# Altium schematic sheet INPUT.SchDoc(INPUT)
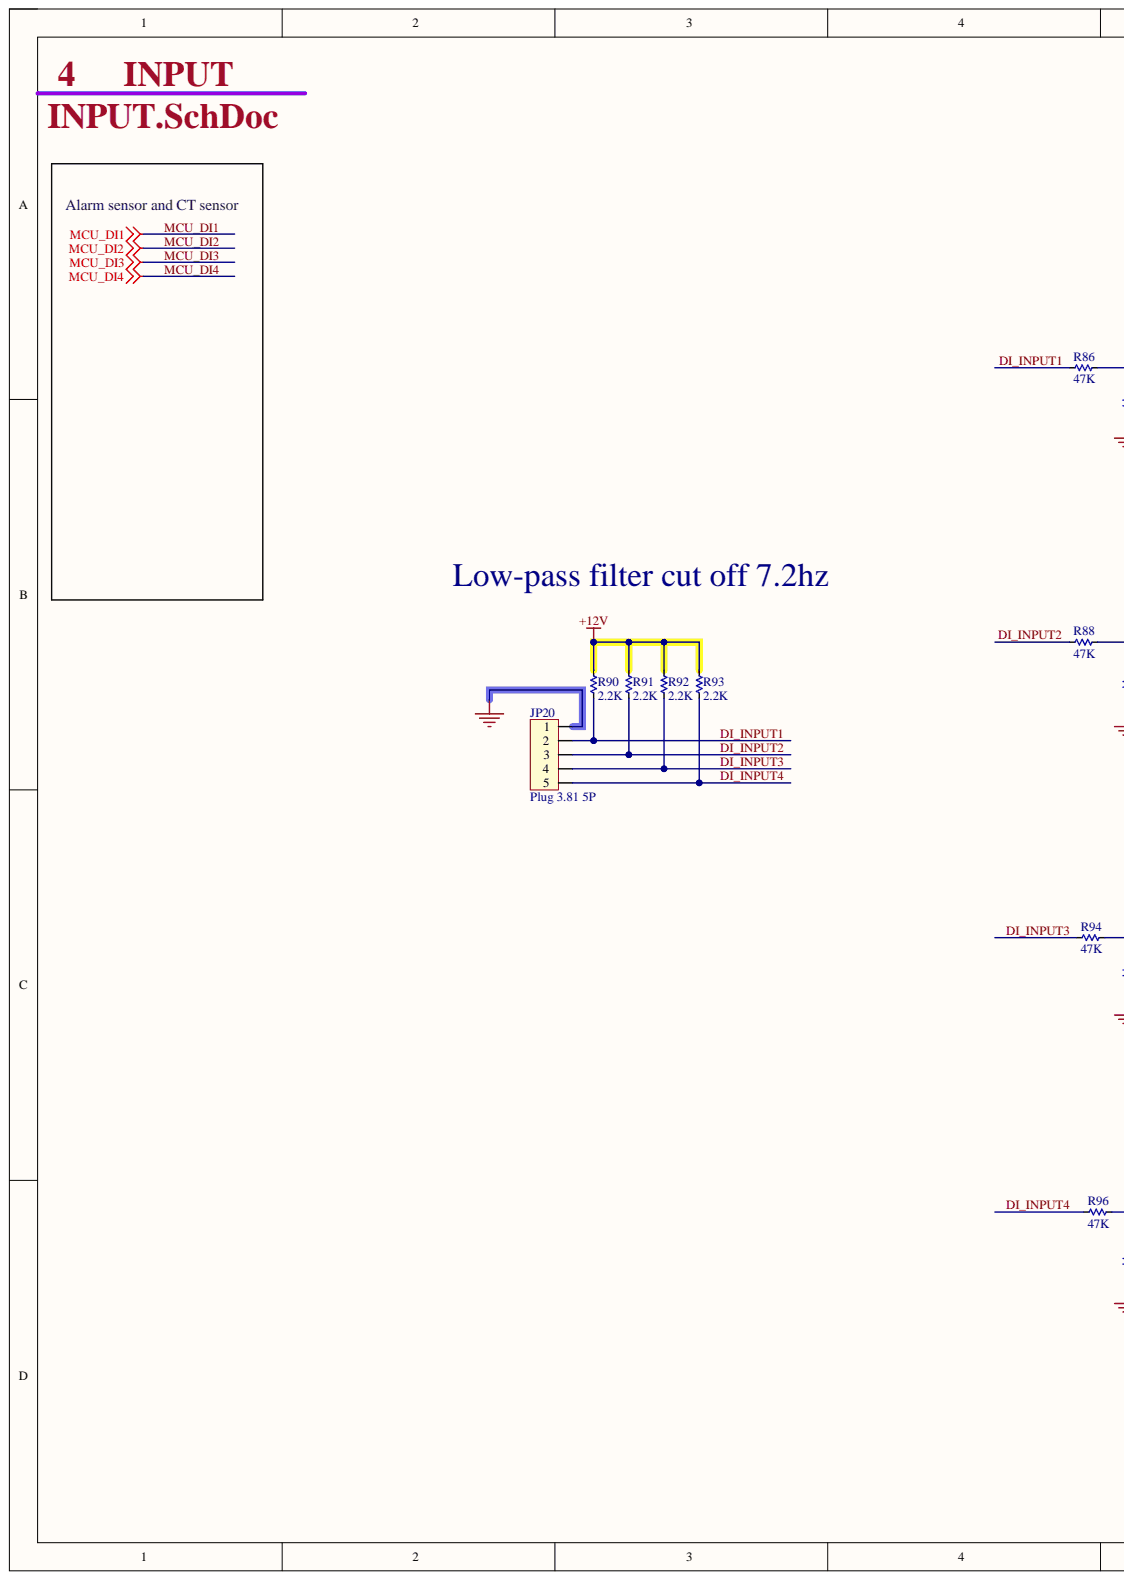
[diagram p0: INPUT.SchDoc, left]
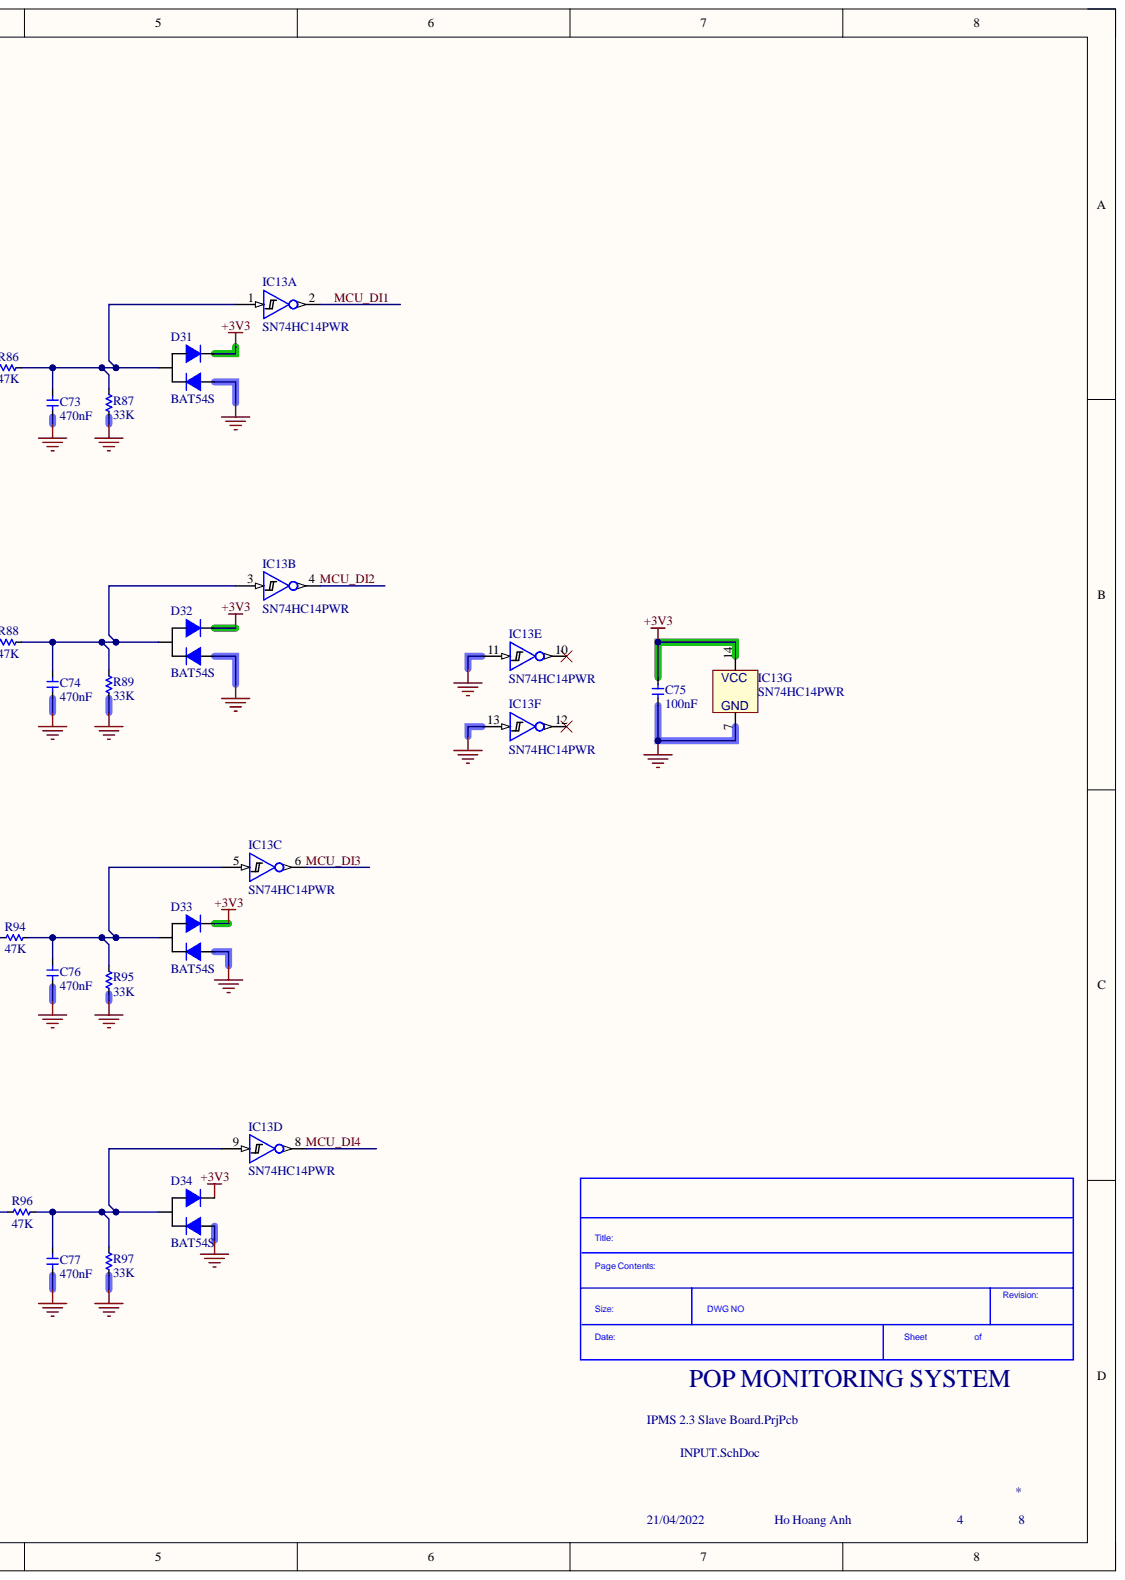
[diagram p1: INPUT.SchDoc, right]

## Parts
C73  470nF  [C_0603]
C74  470nF  [C_0603]
C75  100nF  [C_0603]
C76  470nF  [C_0603]
C77  470nF  [C_0603]
D31  BAT54S  [SOT-23-3]
D32  BAT54S  [SOT-23-3]
D33  BAT54S  [SOT-23-3]
D34  BAT54S  [SOT-23-3]
IC13  SN74HC14PWR  [TSSOP-14_L5.0-W4.4-P0.65-LS6.4-BL]  (parts A,B,C,D,E,F,G)
JP20  Plug 3.81 5P  [CONN-TH_1803455]
R86  47K  [R_0603]
R87  33K  [R_0603]
R88  47K  [R_0603]
R89  33K  [R_0603]
R90  2.2K  [R_0805]
R91  2.2K  [R_0805]
R92  2.2K  [R_0805]
R93  2.2K  [R_0805]
R94  47K  [R_0603]
R95  33K  [R_0603]
R96  47K  [R_0603]
R97  33K  [R_0603]

## Nets
+3V3: C75.2 D31.2 D32.2 D33.2 D34.2 IC13.14
+12V: R90.1 R91.1 R92.1 R93.1
GND: C73.1 C74.1 C75.1 C76.1 C77.1 D31.1 D32.1 D33.1 D34.1 IC13.7 IC13.11 IC13.13 JP20.1 R87.2 R89.2 R95.2 R97.2
DI_INPUT1: JP20.2 R86.2 R90.2
DI_INPUT2: JP20.3 R88.2 R91.2
DI_INPUT3: JP20.4 R92.2 R94.2
DI_INPUT4: JP20.5 R93.2 R96.2
MCU_DI1: IC13.2
MCU_DI2: IC13.4
MCU_DI3: IC13.6
MCU_DI4: IC13.8
NetC73_2: C73.2 D31.3 IC13.1 R86.1 R87.1
NetC74_2: C74.2 D32.3 IC13.3 R88.1 R89.1
NetC76_2: C76.2 D33.3 IC13.5 R94.1 R95.1
NetC77_2: C77.2 D34.3 IC13.9 R96.1 R97.1

Not connected: IC13 10 12

## Library data of the parts
C73 C74 C76 C77 = CL10B474KA8NNNC: 470nF 25V 0603 ROHS; Manufacturer: Samsung Electro-Mechanics; Assembly Type: SMT Assembly; Component Kind: Standard; LCSC Part Number: C1623; Manufacturer Part Number: CL10B474KA8NNNC; Package: 0603; Library Name: IPMS 2.3 Slave Board.SCHLIB
C75 = CC0603KRX7R9BB104: 100nF 50V X7R ±10% 0603 Multilayer Ceramic Capacitors MLCC - SMD/SMT ROHS; Manufacturer: YAGEO; Assembly Type: SMT Assembly; Component Kind: Standard; LCSC Part Number: C14663; Manufacturer Part Number: CC0603KRX7R9BB104; Package: 0603; Library Name: IPMS 2.3 Slave Board.SCHLIB
D31-D34 = BAT54S-7-F: 30V 800mV@100mA 200mA SOT-23(SOT-23-3) Schottky Barrier Diodes (SBD) ROHS; Assembly Type: SMT Assembly; Component Kind: Standard; LCSC Part Number: C83574; Manufacturer: Diodes; Manufacturer Part Number: BAT54S-7-F; Package: SOT-23-3; Library Name: IPMS 2.3 Slave Board.SCHLIB
IC13 = SN74HC14PWR: 74HC14 GATES AND INVERTERS TSSOP-14 ROHS; Manufacturer: Texas Instruments; Assembly Type: SMT Assembly; Component Kind: Standard; LCSC Part Number: C6821; Manufacturer Part Number: SN74HC14PWR; Package: TSSOP-14_4.4x5.0x0.65P; Library Name: IPMS 2.3 Slave Board.SCHLIB
JP20 = 1803455: 160V 8A 4 Straight pin 1 3.81mm Green Board Edge/Receptacle-闭口 P=3.81mm Pluggable System Terminal Block ROHS; Manufacturer: Phoenix Contact; Assembly Type: Hand Soldering; Component Kind: Standard; LCSC Part Number: C480553; Manufacturer Part Number: 1803455; Package: CONN-TH_1803455; Library Name: IPMS 2.3 Slave Board.SCHLIB
R86 R88 R94 R96 = 0603WAF4702T5E: 47KOHMS ±1% 1/10W 0603 ROHS; Manufacturer: Uniroyal Elec; Assembly Type: SMT Assembly; Component Kind: Standard; LCSC Part Number: C25819; Manufacturer Part Number: 0603WAF4702T5E; Package: 0603; Library Name: IPMS 2.3 Slave Board.SCHLIB
R87 R89 R95 R97 = 0603WAF3302T5E: 33KOHMS ±1% 1/10W 0603 ROHS; Manufacturer: Uniroyal Elec; Assembly Type: SMT Assembly; Component Kind: Standard; LCSC Part Number: C4216; Manufacturer Part Number: 0603WAF3302T5E; Package: 0603; Library Name: IPMS 2.3 Slave Board.SCHLIB
R90-R93 = 0805W8F2201T5E: 2.2kΩ 0805 Chip Resistor - Surface Mount ROHS±1% 1/8W Thick Film Resistors 150V ±100ppm/℃ -55℃~+155℃; Manufacturer: Uniroyal Elec; Assembly Type: SMT Assembly; Component Kind: Standard; LCSC Part Number: C17520; Manufacturer Part Number: 0805W8F2201T5E; Package: 0805; Library Name: IPMS 2.3 Slave Board.SCHLIB
